# Altium schematic sheet USB2.0.SchDoc
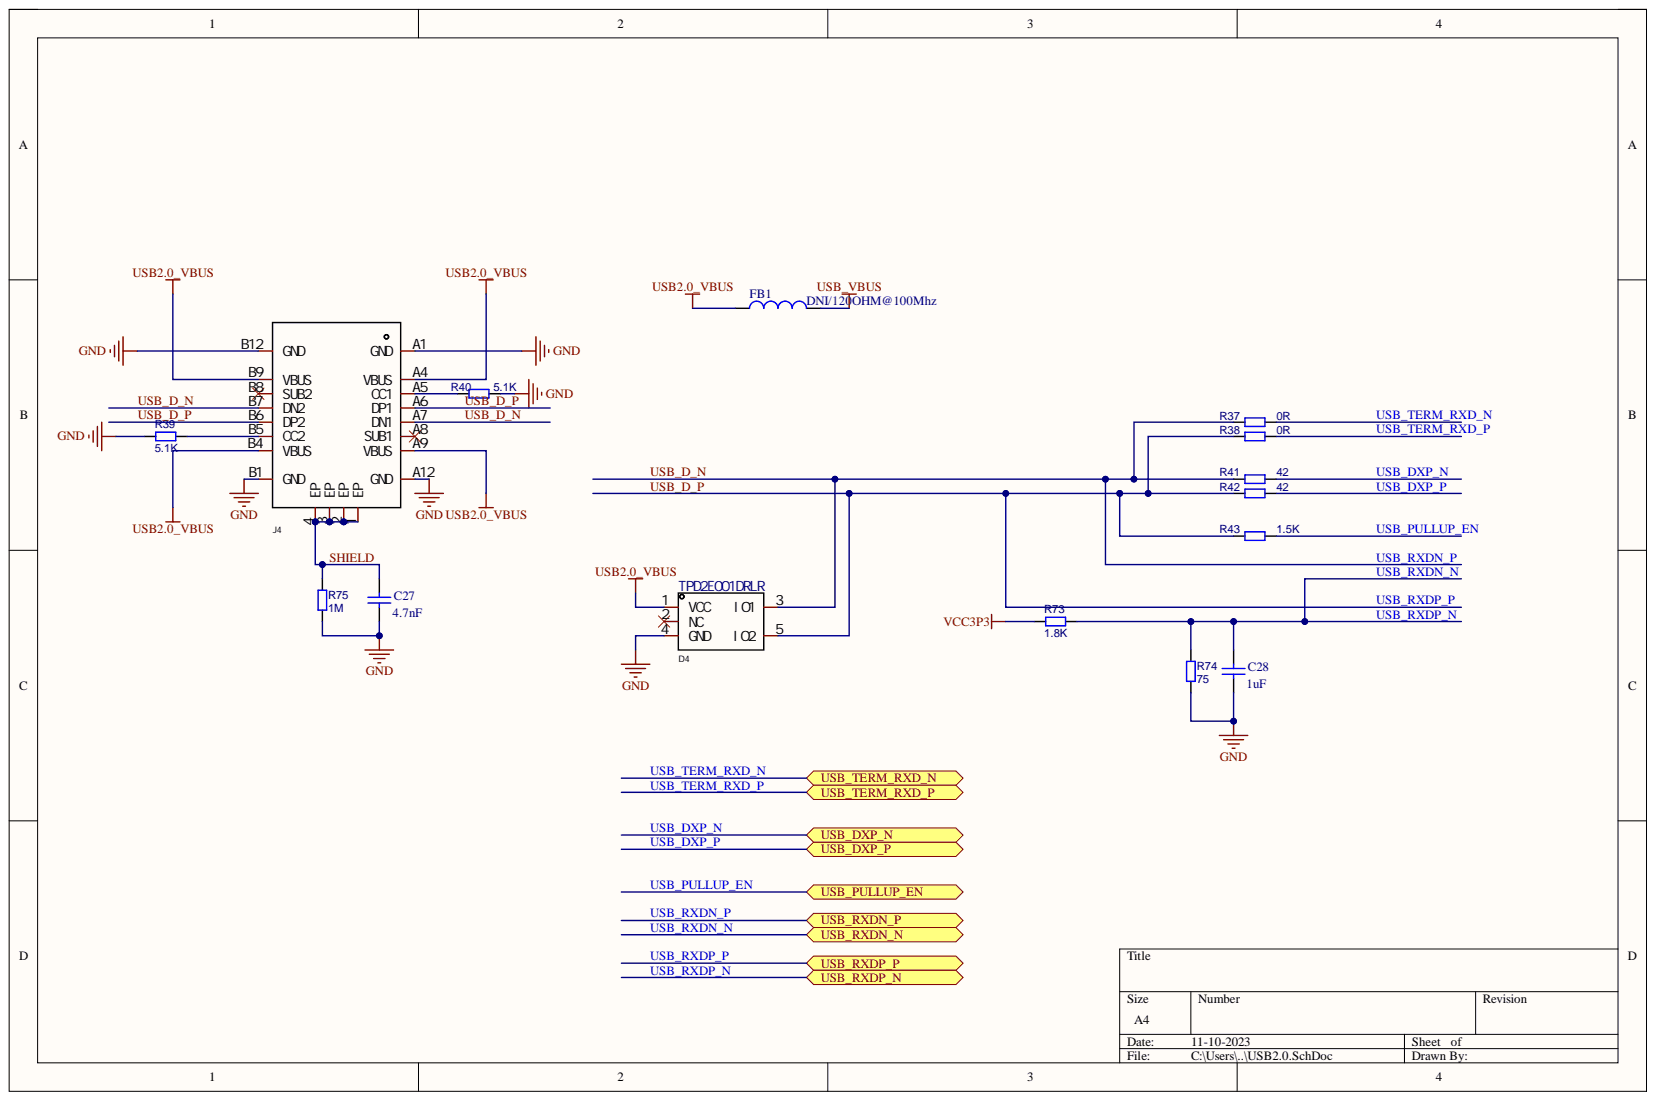
[diagram p0: USB2.0.SchDoc]

## Parts
C27  4.7nF  [C0402]
C28  1uF  [C0603]
D4  TPD2E001DRLR  [SOT-553]
FB1  DNI/120OHM@100Mhz  [L0603]
J4  KH-TYPE-C-16P  [USB_Type-c]  (value not drawn)
R37  0R  [R0402]
R38  0R  [R0402]
R39  5.1K  [R0402]
R40  5.1K  [R0402]
R41  42  [R0402]
R42  42  [R0402]
R43  1.5K  [R0402]
R73  1.8K  [R0402]
R74  75  [R0402]
R75  1M  [R0402]

## Ports
USB_DXP_N, USB_DXP_P, USB_PULLUP_EN, USB_RXDN_N, USB_RXDN_P, USB_RXDP_N, USB_RXDP_P, USB_TERM_RXD_N, USB_TERM_RXD_P

## Nets
GND: C27.1 C28.1 D4.4(GND) J4.A1(GND) J4.A12(GND) J4.B1(GND) J4.B12(GND) R39.2 R40.1 R74.2 R75.2
USB2.0_VBUS: D4.1(VCC) FB1.1 J4.A4(VBUS) J4.A9(VBUS) J4.B4(VBUS) J4.B9(VBUS)
USB_VBUS: FB1.2
VCC3P3: R73.2
SHIELD: C27.2 J4.1(EP) J4.2(EP) J4.3(EP) J4.4(EP) R75.1
USB_D_N: D4.3(IO1) J4.A7(DN1) J4.B7(DN2) R37.1 R41.1 ; port USB_RXDN_P
USB_D_P: D4.5(IO2) J4.A6(DP1) J4.B6(DP2) R38.1 R42.1 R43.1 ; port USB_RXDP_P
USB_DXP_N: R41.2 ; port USB_DXP_N
USB_DXP_P: R42.2 ; port USB_DXP_P
USB_PULLUP_EN: R43.2 ; port USB_PULLUP_EN
USB_RXDN_N: C28.2 R73.1 R74.1 ; port USB_RXDN_N, port USB_RXDP_N
USB_TERM_RXD_N: R37.2 ; port USB_TERM_RXD_N
USB_TERM_RXD_P: R38.2 ; port USB_TERM_RXD_P
NetJ4_A5: J4.A5(CC1) R40.2
NetJ4_B5: J4.B5(CC2) R39.1

Not connected: D4 2(NC); J4 A8(SUB1) B8(SUB2)

## Library data of the parts
C27 C28 = Cap: Capacitor; Component Kind: Standard; LatestRevisionDate: 17-Jul-2002; LatestRevisionNote: Re-released for DXP Platform.; Library Name: Miscellaneous Devices.IntLib; PackageDescription: Radial Cap, Thru-Hole; 2 Leads; 0.3 in Pin Spacing; PackageReference: RAD-0.3; Published: 8-Jun-2000; Signal Integrity: Cap; Simulation: CAP; Publisher: Altium Limited
  C27: Value: 4.7nF
  C28: Value: 1uF
D4 = TPD2E001DRLR_1: Manufacturer: TI(德州仪器); Add into BOM: yes; Breakdown Voltage (Min): 11V; Reverse Stand-Off Voltage (Vrwm): 5.5V@Max; Component Kind: Standard; Convert to PCB: yes; Datasheet: https://atta.szlcsc.com/upload/public/pdf/source/20180403/C150526_E85C8AECB6C0D7CB474458AD5A19A460.pdf; Device: TPD2E001DRLR; JLCPCB Part Class: Extended Part; LCSC Part Name: Vrwm:5.5V@Max; Manufacturer Part: TPD2E001DRLR; Maximum Clamping Voltage: -; Name: TPD2E001DRLR; PCB3D: SOT-553-5P_L1.6-W1.2-H0.6-LS1.6-P0.50; Peak Pulse Current (Ipp)@10/1000μs: -; Peak Pulse Power Dissipation (Ppp)@10/1000μs: -; Supplier: LCSC; Supplier Footprint: SOT-553; Supplier Part: C150526; Symbol: TPD2E001DRLR; Library Name: Gowin.SchLib
FB1 = L: Inductor; Component Kind: Standard; Library Name: plm60x_v001.SchLib
J4 = KH-TYPE-C-16P: Manufacturer: kinghelm(金航标); Add into BOM: yes; Connector Type: Type-C; Current Rating - Power (Max): 3A; Gender: 母座; Number of Contacts: 16; Number of Ports: 1; Component Kind: Standard; Convert to PCB: yes; Datasheet: https://atta.szlcsc.com/upload/public/pdf/source/20200911/C709357_AA8DCEB38BB20E3035A7ED7AE7667837.pdf; Device: KH-TYPE-C-16P; JLCPCB Part Class: Extended Part; LCSC Part Name: Type-C 母座 卧贴; Manufacturer Part: KH-TYPE-C-16P; Mounting Style: 卧贴; Name: KH-TYPE-C-16P; Operating Temperature Range: -40℃~+85℃; PCB3D: USB-C-SMD_KH-TYPE-C-16P; Standard: -; Supplier: LCSC; Supplier Footprint: SMD; Supplier Part: C709357; Symbol: KH-TYPE-C-16P; Library Name: Gowin.SchLib
R37 R38 R41-R43 R73 R74 = R: Value: 4K7; Component Kind: Standard; Library Name: zynq.SCHLIB
R39 R40 R75 = R: Value: DNI/4K7; Component Kind: Standard; Library Name: zynq.SCHLIB
